# Altium schematic sheet USB_Interface.SchDoc
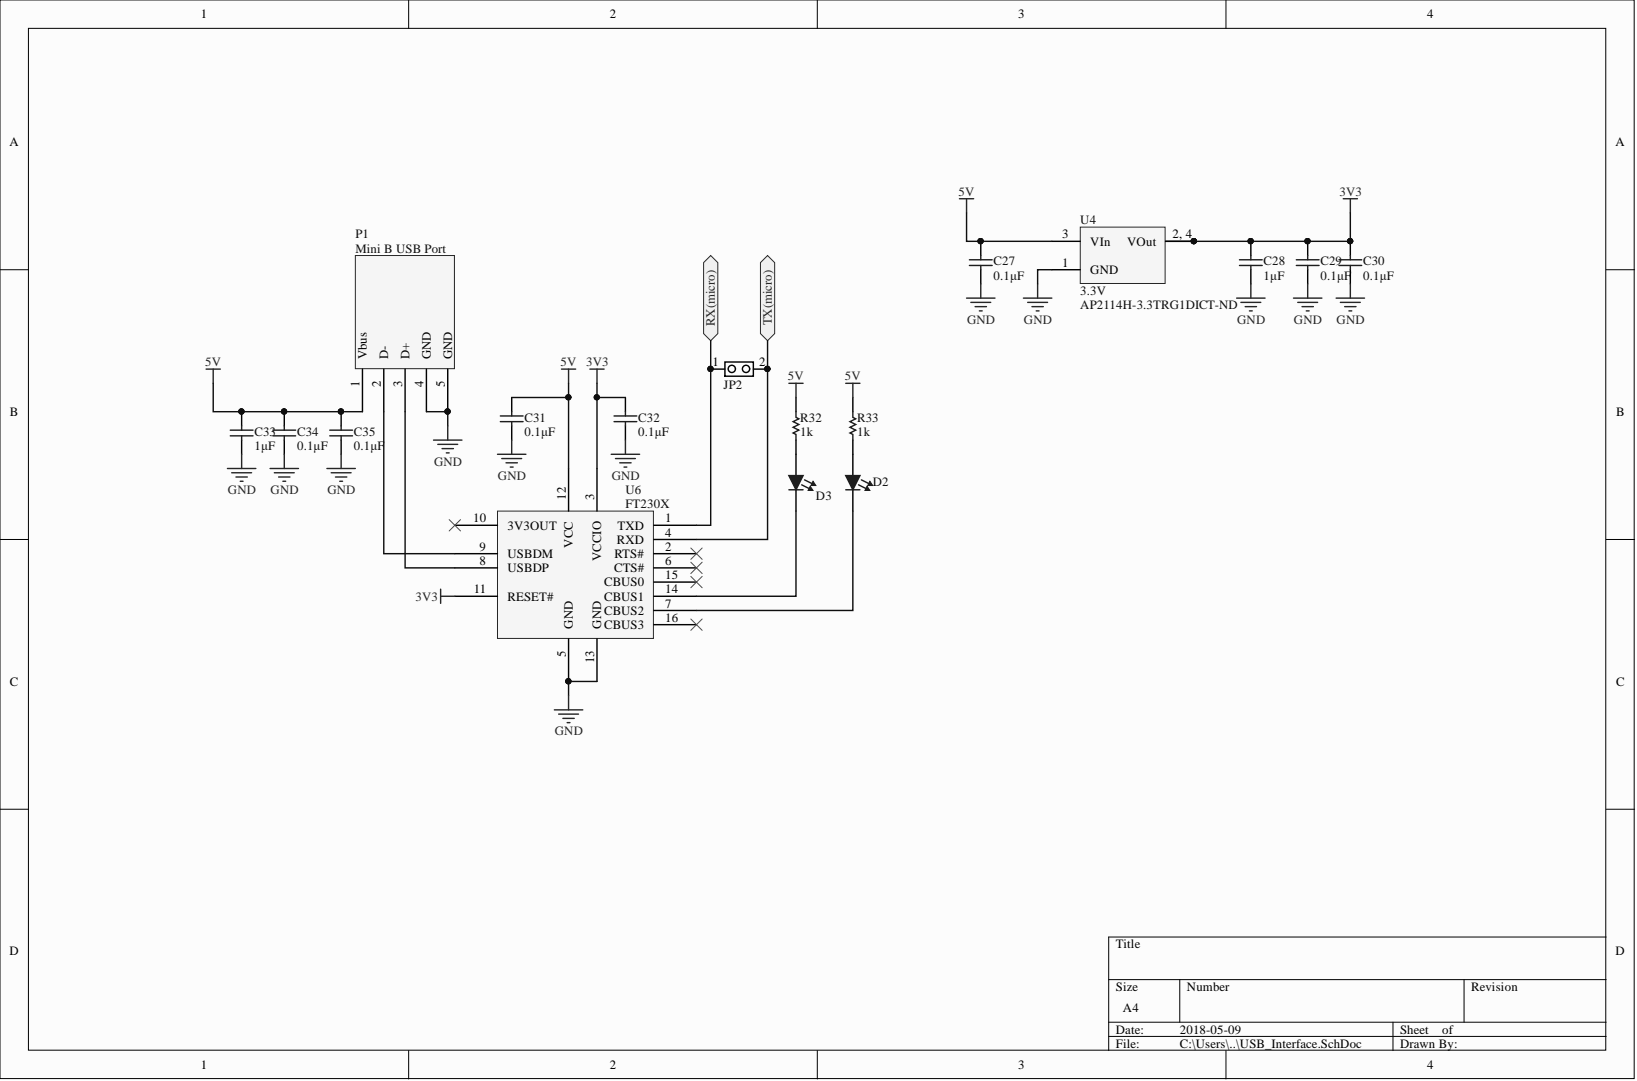
[diagram p0: USB_Interface.SchDoc]

## Parts
C27  0.1μF  [CAPC1608N(0603")]
C28  1μF  [CAPC1608N(0603")]
C29  0.1μF  [CAPC1608N(0603")]
C30  0.1μF  [CAPC1608N(0603")]
C31  0.1μF  [CAPC1608N(0603")]
C32  0.1μF  [CAPC1608N(0603")]
C33  1μF  [CAPC1608N(0603")]
C34  0.1μF  [CAPC1608N(0603")]
C35  0.1μF  [CAPC1608N(0603")]
D2  Red  [LED0603]  (value not drawn)
D3  Red  [LED0603]  (value not drawn)
JP2  1X2 Pin Head  [2X1 Males Header]  (value not drawn)
P1  Mini B USB Port  [Mini B USB Footprint]
R32  1k  [RESC1608N(0603")]
R33  1k  [RESC1608N(0603")]
U4  3.3V  Supplier 1 Part Number: AP2114H-3.3TRG1DICT-ND  [SOT-223-4pin]
U6  FT230X  [SSOP16]

## Ports
RX(micro), TX(micro)

## Nets
3V3: C28.2 C29.2 C30.2 C32.2 U4.2(VOut) U4.4 U6.3(VCCIO) U6.11(RESET#)
5V: C27.2 C31.2 C33.2 C34.2 C35.2 P1.1(Vbus) R32.2 R33.2 U4.3(VIn) U6.12(VCC)
GND: C27.1 C28.1 C29.1 C30.1 C31.1 C32.1 C33.1 C34.1 C35.1 P1.4(GND) P1.5(GND) U4.1(GND) U6.5(GND) U6.13(GND)
RX(micro): JP2.1 U6.1(TXD) ; port RX(micro)
TX(micro): JP2.2 U6.4(RXD) ; port TX(micro)
NetD2_1: D2.1 U6.7(CBUS2)
NetD2_2: D2.2 R33.1
NetD3_1: D3.1 U6.14(CBUS1)
NetD3_2: D3.2 R32.1
NetP1_2: P1.2(D-) U6.9(USBDM)
NetP1_3: P1.3(D+) U6.8(USBDP)

Not connected: U6 2(RTS#) 6(CTS#) 10(3V3OUT) 15(CBUS0) 16(CBUS3)

## Library data of the parts
C27 C29-C32 C34 C35 = 0.1uF 100V 0603 SMD, Ceramic: Capacitor, Ceramic, 100V, +/- 10%, 0603 SMD, X7R; MFG: TDK; MFG#: CGA3E3X7S2A104K080AB; Component Kind: Standard; PackageDescription: Chip Capacitor; Published: 16-04-26; Supplier 1: Digi-Key; Supplier Part Number 1: 445-6938-1-ND; Value: 0.1μF; Created By: Ashwin Sira; Library Name: AUVic_Integrated_Library_Capacitors.IntLib; Publisher: AUVic
C28 C33 = 1uF 25V 0603 SMD, Ceramic: Capacitor, Ceramic, 25V, +/- 10%, 0603 SMD, X5R; MFG: Murata-Electronics-North-America; MFG#: GRM188R61E105KA12D; Component Kind: Standard; PackageDescription: Chip Capacitor; Published: 16-06-27; Supplier 1: Digi-Key; Supplier Part Number 1: 490-3897-1-ND; Value: 1μF; Created By: Andy Bates; Library Name: AUVic_Integrated_Library_Capacitors.IntLib; Publisher: AUVic
D2 = LED, 0805 (2012), Green, Clear: Colour: Red; Component Kind: Standard; Description: LED, 0805 (2012), Green, Clear; Supplier 1: Digi-Key; Supplier Part Number 1: 475-1410-1-ND; Created By: Andy Bates; Library Name: AUVic_Integrated_Library_Diodes.IntLib
D3 = LED, 0805 (2012), Red, Clear: Colour: Red; Component Kind: Standard; Description: LED, 0805 (2012), Red, Clear; Supplier 1: Digi-Key; Supplier Part Number 1: 732-4984-1-ND; Created By: Ashwin Sira; Library Name: AUVic_Integrated_Library_Diodes.IntLib
JP2 = 1x2 Male Header: Made By: Andy Bates; Component Kind: Standard; Supplier 1: Digikey; Supplier 1 Part Number: 952-3073-ND; Library Name: AUVic_Integrated_Library_Connectors.IntLib
P1 = Mini B USB Port: Mini B USB Port - Female; Component Kind: Standard; Created on: July 5, 2017; Created by: Gabriel Green; Library Name: AUVic_Schematic_Library_Miscellaneous.SchLib
R32 R33 = 1kR, 1%, 1/10W , 0603, SMD: Component Kind: Standard; Date Created: 2017-05-10; Description: 1kR, 1%, 1/10W , 0603, SMD; Supplier 1: Digikey; Supplier Part Number 1: 311-1.00KHRCT-ND; Value: 1k; Created By: Andy Bates; Library Name: AUVic_Schematic_Library_Resistors.SchLib; Publisher: AUVic
U4 = Linear, 3.3V, 1A, 3.3W, SMT: Made By: Andy Bates; VIn Max: 6V; Component Kind: Standard; Description: Linear, 3.3V, 1A, 3.3W, SMT; Supplier 1: Digi-Key; Supplier Part Number 1: AP2114H-3.3TRG1DICT-ND; VOut: 3.3V; Library Name: AUVic_Integrated_Library_Regulators.IntLib
U6 = FTDI - USB to UART: Component Kind: Standard; Created on: July 5th, 2017; Supplier 1: Digi-Key; Supplier Part Number 1: 768-1135-1-ND; Created by: Gabriel Green; Library Name: AUVic_Integrated_Library_Interface.IntLib
